# Altium schematic sheet Power_Distro_Rev2.SchDoc
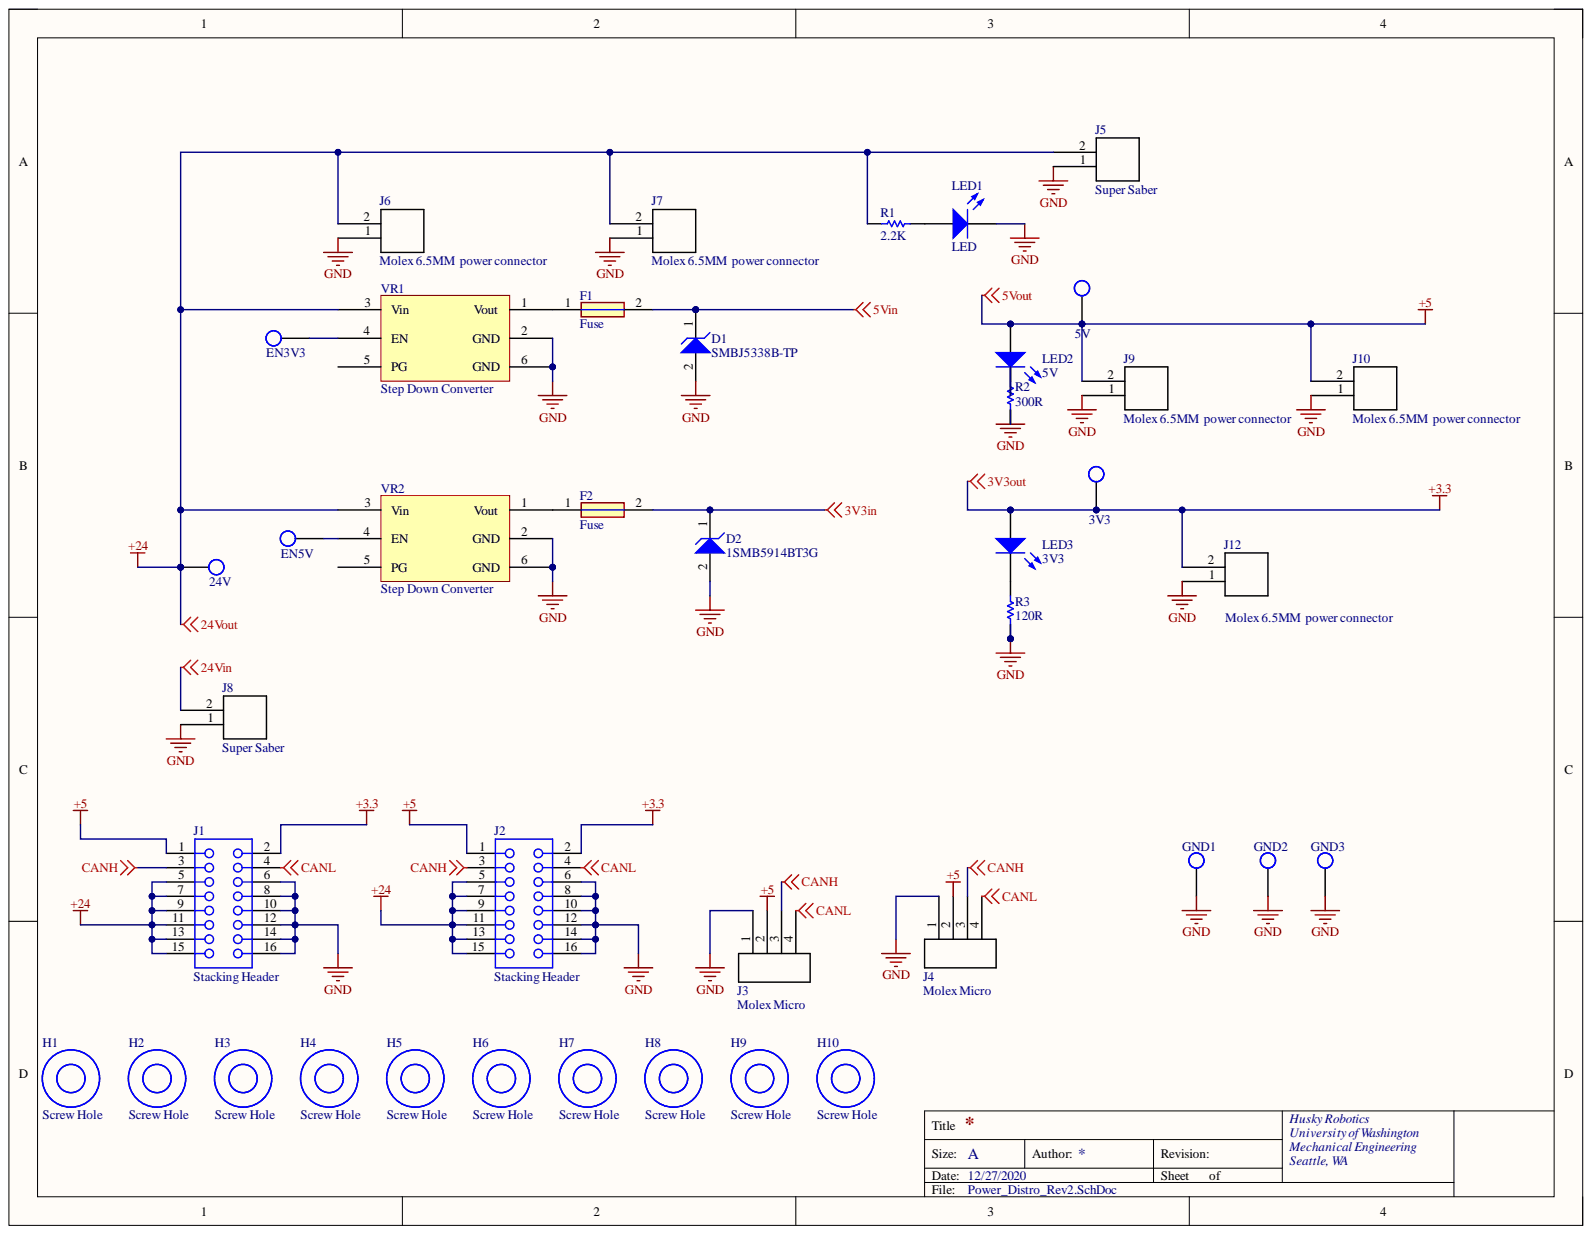
[diagram p0: Power_Distro_Rev2.SchDoc]

## Parts
3V3  Test Point  [Test Point Through-hole]  (value not drawn)
5V  Test Point  [Test Point Through-hole]  (value not drawn)
24V  Test Point  [Test Point Through-hole]  (value not drawn)
D1  SMBJ5338B-TP  [ONSC-SMB-2-403A-03_H_V]
D2  1SMB5914BT3G  [ONSC-SMB-2-403A-03_H_V]
EN3V3  Test Point  [Test Point Through-hole]  (value not drawn)
EN5V  Test Point  [Test Point Through-hole]  (value not drawn)
F1  Fuse  [Fuse_Through_Hole - duplicate]
F2  Fuse  [Fuse_Through_Hole - duplicate]
GND1  Test Point  [Test Point Through-hole]  (value not drawn)
GND2  Test Point  [Test Point Through-hole]  (value not drawn)
GND3  Test Point  [Test Point Through-hole]  (value not drawn)
H1  Screw Hole  [Screw Hole]
H2  Screw Hole  [Screw Hole]
H3  Screw Hole  [Screw Hole]
H4  Screw Hole  [Screw Hole]
H5  Screw Hole  [Screw Hole]
H6  Screw Hole  [Screw Hole]
H7  Screw Hole  [Screw Hole]
H8  Screw Hole  [Screw Hole]
H9  Screw Hole  [Screw Hole]
H10  Screw Hole  [Screw Hole]
J1  Stacking Header  [SMTC-SSQ-108-02-X-D]
J2  Stacking Header  [SMTC-SSQ-108-02-X-D]
J3  Molex Micro  [MICROFIT R/A 4 PIN]
J4  Molex Micro  [MICROFIT R/A 4 PIN]
J5  Super Saber  [SuperSaber R/A]
J6  Molex 6.5MM  power connector  [power connector]
J7  Molex 6.5MM  power connector  [power connector]
J8  Super Saber  [SuperSaber R/A]
J9  Molex 6.5MM  power connector  [power connector]
J10  Molex 6.5MM  power connector  [power connector]
J12  Molex 6.5MM  power connector  [power connector]
LED1  LED  [0805_A]
LED2  5V  [0805_A]
LED3  3V3  [0805_A]
R1  value 2.2K  [RESC0805(2012)_N]  (hidden comment: Resistor 0805)
R2  value 300R  [RESC0805(2012)_N]  (hidden comment: Resistor 0805)
R3  value 120R  [RESC0805(2012)_N]  (hidden comment: Resistor 0805)
VR1  Step Down Converter  [24V-5V Converter]
VR2  Step Down Converter  [24V-5V Converter]

## Nets
3V3in: D2.1 F2.2
3V3out: 3V3.1 J1.2 J2.2 J12.2 LED3.2
5Vin: D1.1 F1.2
5Vout: 5V.1 J1.1 J2.1 J3.2 J4.2 J9.2 J10.2 LED2.2
24Vin: J8.2
24Vout: 24V.1 J1.5 J1.7 J1.9 J1.11 J1.13 J1.15 J2.5 J2.7 J2.9 J2.11 J2.13 J2.15 J5.2 J6.2 J7.2 R1.1 VR1.3(Vin) VR2.3(Vin)
CANH: J1.3 J2.3 J3.3 J4.3
CANL: J1.4 J2.4 J3.4 J4.4
GND: D1.2 D2.2 GND1.1 GND2.1 GND3.1 J1.6 J1.8 J1.10 J1.12 J1.14 J1.16 J2.6 J2.8 J2.10 J2.12 J2.14 J2.16 J3.1 J4.1 J5.1 J6.1 J7.1 J8.1 J9.1 J10.1 J12.1 LED1.1 R2.1 R3.1 VR1.2(GND) VR1.6(GND) VR2.2(GND) VR2.6(GND)
NetEN3V3_1: EN3V3.1 VR1.4(EN)
NetEN5V_1: EN5V.1 VR2.4(EN)
NetF1_1: F1.1 VR1.1(Vout)
NetF2_1: F2.1 VR2.1(Vout)
NetLED1_2: LED1.2 R1.2
NetLED2_1: LED2.1 R2.2
NetLED3_1: LED3.1 R3.2

Not connected: VR1 5(PG); VR2 5(PG)

## Library data of the parts
D1 D2 = Zener Diode 5.1V: 3 W Zener Voltage Regulator, 2-Pin SMB, Pb-Free, Tape and Reel; Manufacturer: On Semiconductor; PartNumber: 1SMB5917BT3G; VZ Typ (V): 4.7; Component Kind: Standard; ComponentLink1Description: Manufacturer URL; ComponentLink1URL: http://www.onsemi.com/; ComponentLink2Description: Datasheet; ComponentLink2URL: http://www.onsemi.com/pub_link/Collateral/1SMB5913BT3-D.PDF; ComponentLink3Description: Package Specification; ComponentLink3URL: http://www.onsemi.com/pub_link/Collateral/1SMB5913BT3-D.PDF; DatasheetVersion: Rev. 8; Mounting Technology: Surface Mount; PackageDescription: 2-Pin Small Molded Package, J-Bend Lead, Body 4.33 x 3.625 mm; PackageReference: SMB-2-403A-03_H; PackageVersion: Rev. H, 05/2011; Packing: Tape and Reel; RoHS: Pb-Free; Library Name: Husky Robotics Standard Library 2019-2020.IntLib
H1-H10 = CMP-012-0001-1: Add 4 of these to your design for screw hole mounting; Component Kind: Standard; Component Type: Standard; Design Item ID: Screw Hole; Pin Count: 0; Source: Screw Hole.SchLib; Library Name: University of Washington - Husky Robotics Team
J1 J2 = Stacking Header: SQ Post Socket, Through-hole, Vertical, -55 to 125 degC, 2.54 mm Pitch, 16-Pin, Female, RoHS; Manufacturer: Samtec; PartNumber: SSQ-108-02-G-D; Pitch: 2.54 mm; Component Kind: Standard; ComponentLink1Description: Manufacturer URL; ComponentLink1URL: http://www.samtec.com; ComponentLink2Description: Datasheet; ComponentLink2URL: http://www.samtec.com/documents/webfiles/pdf/SSQ_TH.PDF; ComponentLink3Description: Package Specification; ComponentLink3URL: http://www.samtec.com/documents/webfiles/cpdf/SSQ-1XX-XX-XXX-X-XX-XXX-XX-X-MKT.pdf; DatasheetVersion: F-214; Mounting Technology: Through-hole; Orientation: Vertical; PackageDescription: Assembly, Socket Strip .025[0.64] SQ Tail; PackageReference: SSQ-108-02-X-D; PackageVersion: BD, 6/1987; RoHS: True; Temperature Range: -55 to 125 degC; Library Name: Husky Robotics Standard Library 2019-2020.IntLib
J3 J4 = Molex micro-fit 4-pin (Single row schematic): Molex micro-fit 3.0 dual row header. P/N 0430450427; Component Kind: Standard; Library Name: Husky Robotics Standard Library 2019-2020.IntLib
LED1-LED3 = LED: Standard 0805 LED; Manufacturer: Wuerth Elektronik; PartNumber: 150080SS75000; Color: Super Red; Component Kind: Standard; ComponentLink1Description: Manufacturer URL; ComponentLink1URL: http://www.we-online.com; ComponentLink2Description: Datasheet; ComponentLink2URL: http://katalog.we-online.com/led/datasheet/150080SS75000.pdf; PackageReference: 0805_A; Library Name: Husky Robotics Standard Library 2019-2020.IntLib
R1-R3 = Resistor 0805: Generic 0805 Resistor; Case-EIA: 0805; Case-Metric: 2012; Power: 0.125 W; Tolerance: 5 %; Component Kind: Standard; Technology: SMT; Library Name: Husky Robotics Standard Library 2019-2020.IntLib
VR1 VR2 = 24V-5V Pololu 2858: Component Kind: Standard; Library Name: Husky Robotics Standard Library 2019-2020.IntLib
3V3 5V 24V EN3V3 EN5V GND1-GND3 = Test Point: Component Kind: Standard; Library Name: Husky Robotics Standard Library 2019-2020.IntLib
F1 F2 = Fuse: Component Kind: Standard; Library Name: Husky Robotics Standard Library 2019-2020.IntLib
J5 J8 = Super Saber: Component Kind: Standard; Library Name: Husky Robotics Standard Library 2019-2020.IntLib
J6 J7 J9 J10 J12 = Molex 6.5MM  power connector: Component Kind: Standard; Library Name: Husky Robotics Standard Library 2019-2020.IntLib
